# Altium schematic sheet Sheet 3 - Alims.SchDoc("Sheet 3 - Alims")
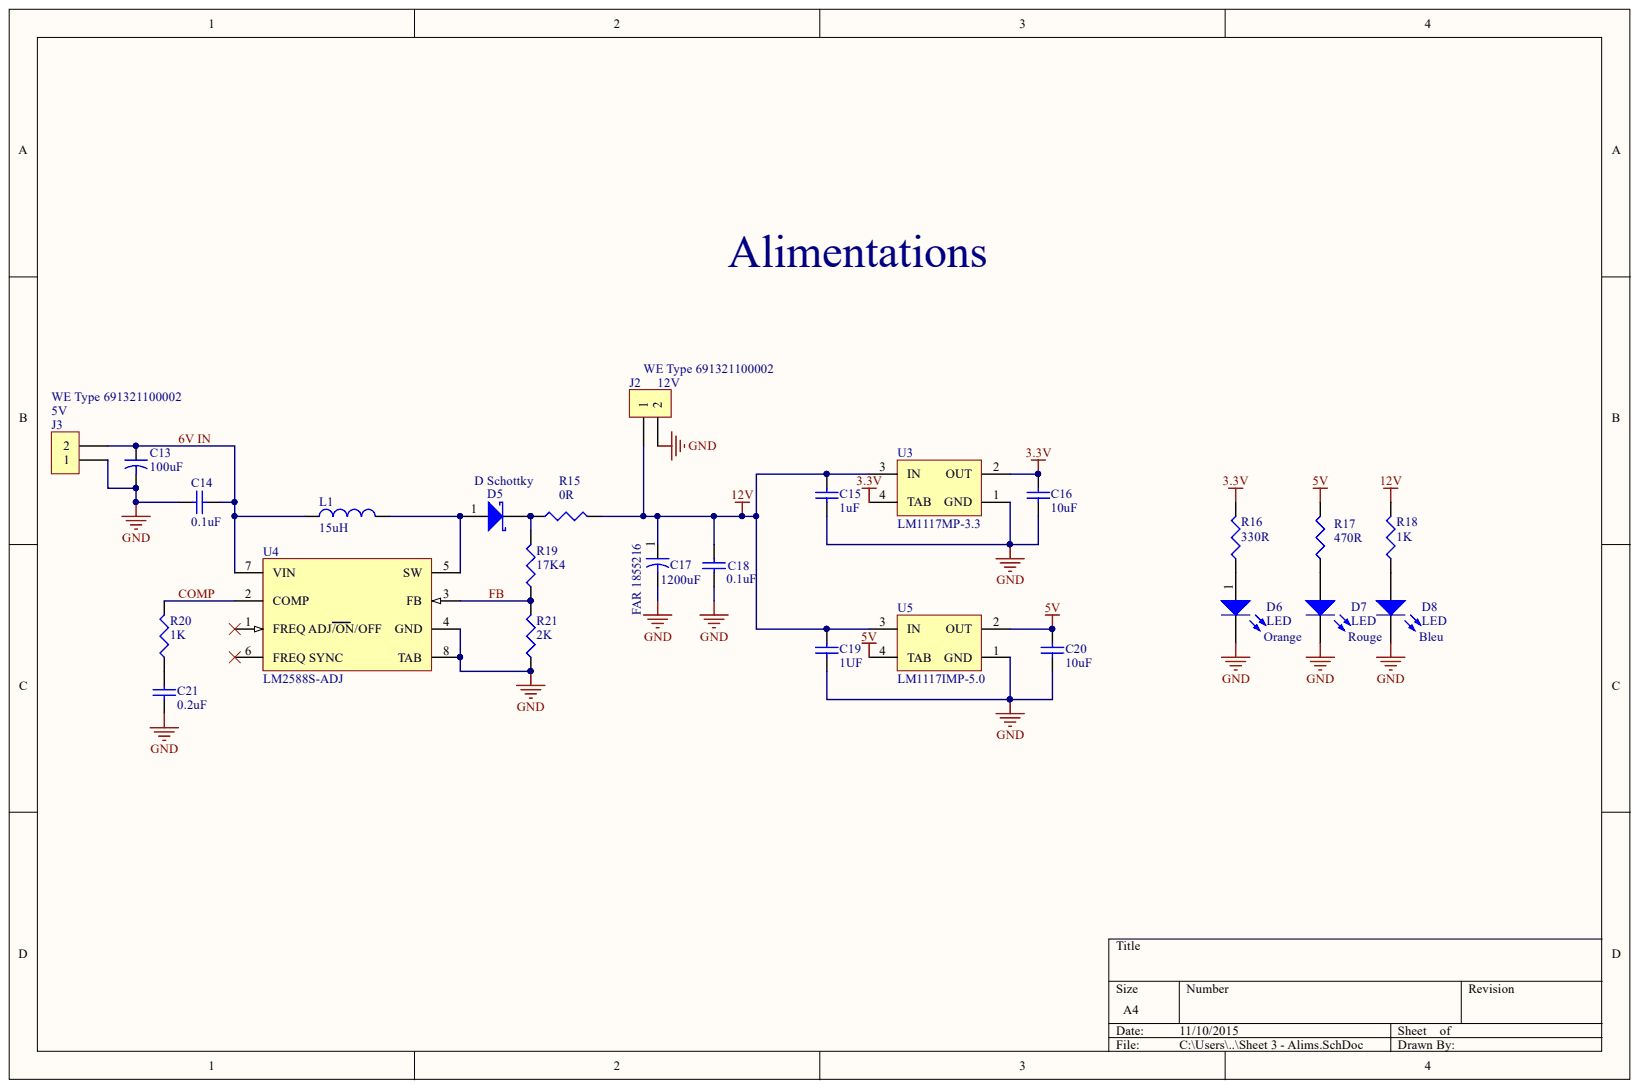
[diagram p0: Sheet 3 - Alims.SchDoc]

## Parts
C13  100uF  [C1206]
C14  0.1uF  [C0805]
C15  1uF  [C0805]
C16  10uF  [C0805]
C17  1200uF  référence commande: FAR 1855216  [CAPA_D10_E5]
C18  0.1uF  [C0805]
C19  1UF  [C0805]
C20  10uF  [C0805]
C21  0.2uF  [C0805]
D5  D Schottky  [D0805]
D6  LED  value Orange  [LED 0805]
D7  LED  value Rouge  [LED 0805]
D8  LED  value Bleu  [LED 0805]
J2  WE Type 691321100002  value 12V  [691321100002]
J3  WE Type 691321100002  value 5V  [691321100002]
L1  15uH  [Inductance 4.06 x 4.45]
R15  0R  [0805]
R16  330R  [0805]
R17  470R  [0805]
R18  1K  [0805]
R19  17K4  [0805]
R20  1K  [0805]
R21  2K  [0805]
U3  LM1117MP-3.3  [MP04A_N]
U4  LM2588S-ADJ  [TS7B_N]
U5  LM1117IMP-5.0  [MP04A_N]

## Nets
3.3V: C16.2 R16.2 U3.2(OUT) U3.4(TAB)
5V: C20.2 R17.2 U5.2(OUT) U5.4(TAB)
12V: C15.2 C17.1 C18.2 C19.2 J2.1 R15.2 R18.2 U3.3(IN) U5.3(IN)
GND: C13.2 C14.1 C15.1 C16.1 C17.2 C18.1 C19.1 C20.1 C21.1 D6.2 D7.2 D8.2 J2.2 J3.2 R21.1 U3.1(GND) U4.4(GND) U4.8(TAB) U5.1(GND)
6V IN: C13.1 C14.2 J3.1 L1.1 U4.7(VIN)
COMP: R20.2 U4.2(COMP)
FB: R19.1 R21.2 U4.3(FB)
NetC21_2: C21.2 R20.1
NetD5_1: D5.1 L1.2 U4.5(SW)
NetD5_2: D5.2 R15.1 R19.2
NetD6_1: D6.1 R16.1
NetD7_1: D7.1 R17.1
NetD8_1: D8.1 R18.1

Not connected: U4 1(FREQ_ADJ/ON/OFF) 6(FREQ_SYNC)

## Library data of the parts
C13 = Cap2: Capacitor 1206; Component Kind: Standard; Library Name: Miscellaneous Devices.IntLib; PackageReference: CAPR5-4X5; Published: 23-Sep-2002; reference farnell: 2211193; Signal Integrity: Cap; Simulation: CAP; Value: 100uF; Publisher: Altium Limited
C14-C16 C18-C21 = Cap: Capacitor 0805; Component Kind: Standard; LatestRevisionDate: 17-Jul-2002; LatestRevisionNote: Re-released for DXP Platform.; Library Name: Miscellaneous Devices.IntLib; PackageReference: RAD-0.3; Published: 8-Jun-2000; Signal Integrity: Cap; Simulation: CAP; Publisher: Altium Limited
  C14 C18: Value: 0.1uF
  C15 C19: Value: 1uF
  C16 C20: Value: 10uF
  C21: Value: 0.2uF
C17 = Cap2: EEUFM1C122L; Component Kind: Standard; Library Name: Miscellaneous Devices.IntLib; PackageReference: CAPR5-4X5; Published: 23-Sep-2002; Signal Integrity: Cap; Simulation: CAP; Value: 1200uF; Publisher: Altium Limited
D5 = D Schottky: Schottky Diode 0805; value: Diode Schotky; Code_JEDEC: DO-214-AA; Component Kind: Standard; LatestRevisionDate: 17-Jul-2002; LatestRevisionNote: Re-released for DXP Platform.; Library Name: Miscellaneous Devices.IntLib; PackageReference: SMB; Published: 8-Jun-2000; Signal Integrity: D Schottky; Simulation: SKYDIODE; Publisher: Altium Limited
D6-D8 = LED2: Typical RED, GREEN, YELLOW, AMBER GaAs LED 0805; Component Kind: Standard; LatestRevisionDate: 17-Jul-2002; LatestRevisionNote: Re-released for DXP Platform.; Library Name: Miscellaneous Devices.IntLib; PackageReference: 3.2X1.6X1.1; PackageVersion: Feb-2002; Published: 8-Jun-2000; Simulation: LED2; Publisher: Altium Limited
J2 J3 = WE-Terminal Blocks Plugable Type 691321100002: WR-TBL Terminal block - serie 3211 - 3.50 mm; Manufacturer: W¨¹rth Elektronik eiSos GmbH; ComponentLink1 Description: Manufacturer; ComponentLink2 Description: Datasheet; NoPins: 2; Component Kind: Standard; ComponentLink1URL: http://www.we-online.com; ComponentLink2URL: http://katalog.we-online.de/em/datasheet/6913211000xx.pdf; Created Date: 9 December 2013; Library Name: 6913211000xx.IntLib; partNo: 691321100002; Created by: Karrer Zheng
L1 = Inductor: SRP4020TA-150M; Code_IEC: 1005; Code_JEITA: 0402; Component Kind: Standard; LatestRevisionDate: 29-May-2009; LatestRevisionNote: IPC-7351 Footprint Added.; Library Name: Miscellaneous Devices.IntLib; PackageDescription: Chip Inductor; PackageReference: 0402-A; Published: 8-Jun-2000; Signal Integrity: Inductor; Simulation: INDUCTOR; Value: 15uH; Publisher: Altium Limited
R15-R21 = Res3: Resistor 0805; Component Kind: Standard; LatestRevisionDate: 29-May-2009; LatestRevisionNote: IPC-7351 Footprint Added.; Library Name: Miscellaneous Devices.IntLib; PackageDescription: Chip Resistor; PackageReference: J1-0603; Published: 8-Jun-2000; Signal Integrity: Res; Simulation: RESISTOR; Publisher: Altium Limited
  R15: Value: 0R
  R16: Value: 330R
  R17: Value: 470R
  R18 R20: Value: 1K
  R19: Value: 17K4
  R21: Value: 2k
U3 = LM1117MP-3.3: 800mA Low-Dropout Linear Regulator; Manufacturer: National Semiconductor; CompType: LDO IC; PackageTopMarking: XYTT*N05A; PowerWise: N; Code_IPC: SOT223-4; Code_JEDEC: TO-261-AA; Component Kind: Standard; ComponentLink1Description: LM1117 Datasheet; ComponentLink1URL: http://www.national.com/ds/LM/LM1117.pdf; ComponentLink2Description: LM1117 Web Page; ComponentLink2URL: http://www.national.com/pf/LM/LM1117.html; ComponentLink3Description: MP04A Package Page; ComponentLink3URL: http://www.national.com/packaging/folders/mp04a.html; DatasheetVersion: Apr-2006; DigiKeyPartNumber: LM1117MP-3.3CT-ND; Library Name: NSC LDO.IntLib; PackageDescription: Molded SOT-223, 6.50 x 3.56 x 1.6mm Body, 4 Lead, 2.3mm Pitch; PackageReference: MP04A; PackageVersion: revB, Apr-2003; PartNumber: LM1117MP-3.3; PinCount: 4; Published: 4-Dec-2008; RoHS: O; Publisher: Altium Limited
U4 = LM2588S-ADJ: SIMPLE SWITCHER 5A Flyback Regulator with Shutdown; Manufacturer: National Semiconductor; PackageTopMarking: NSUZXYTT*LM2588S*-ADJ P+; PowerWise: N; Code_JEDEC: MO-169-AC; Component Kind: Standard; ComponentLink1Description: LM2588 Datasheet; ComponentLink1URL: http://www.national.com/ds/LM/LM2588.pdf; ComponentLink2Description: LM2588 Web Page; ComponentLink2URL: http://www.national.com/pf/LM/LM2588.html; ComponentLink3Description: TS7B Package Page; ComponentLink3URL: http://www.national.com/packaging/folders/ts7b.html; CompType: Switcher; DatasheetVersion: Jul-2005; DigiKeyPartNumber: LM2588S-ADJ-ND; FirstPublished: 4-Dec-2008; Library Name: NSC Switcher.IntLib; PackageDescription: TO-263, Molded, .400 x .390 x .180in Body, 7 Lead, .050in Pitch, Surface Mount; PackageReference: TS7B; PackageVersion: revE, Jul-2003; PartNumber: LM2588S-ADJ; PinCount: 7; RoHS: O; Publisher: Altium Limited
U5 = LM1117IMP-5.0: 800mA Low-Dropout Linear Regulator; Manufacturer: National Semiconductor; CompType: LDO IC; PackageTopMarking: XYTT*N06B; PowerWise: N; Code_IPC: SOT223-4; Code_JEDEC: TO-261-AA; Component Kind: Standard; ComponentLink1Description: LM1117 Datasheet; ComponentLink1URL: http://www.national.com/ds/LM/LM1117.pdf; ComponentLink2Description: LM1117 Web Page; ComponentLink2URL: http://www.national.com/pf/LM/LM1117.html; ComponentLink3Description: MP04A Package Page; ComponentLink3URL: http://www.national.com/packaging/folders/mp04a.html; DatasheetVersion: Apr-2006; DigiKeyPartNumber: LM1117IMP-5.0CT-ND; Library Name: NSC LDO.IntLib; PackageDescription: Molded SOT-223, 6.50 x 3.56 x 1.6mm Body, 4 Lead, 2.3mm Pitch; PackageReference: MP04A; PackageVersion: revB, Apr-2003; PartNumber: LM1117IMP-5.0; PinCount: 4; Published: 4-Dec-2008; RoHS: O; Publisher: Altium Limited
